# Altium schematic sheet Battery Protection.SchDoc
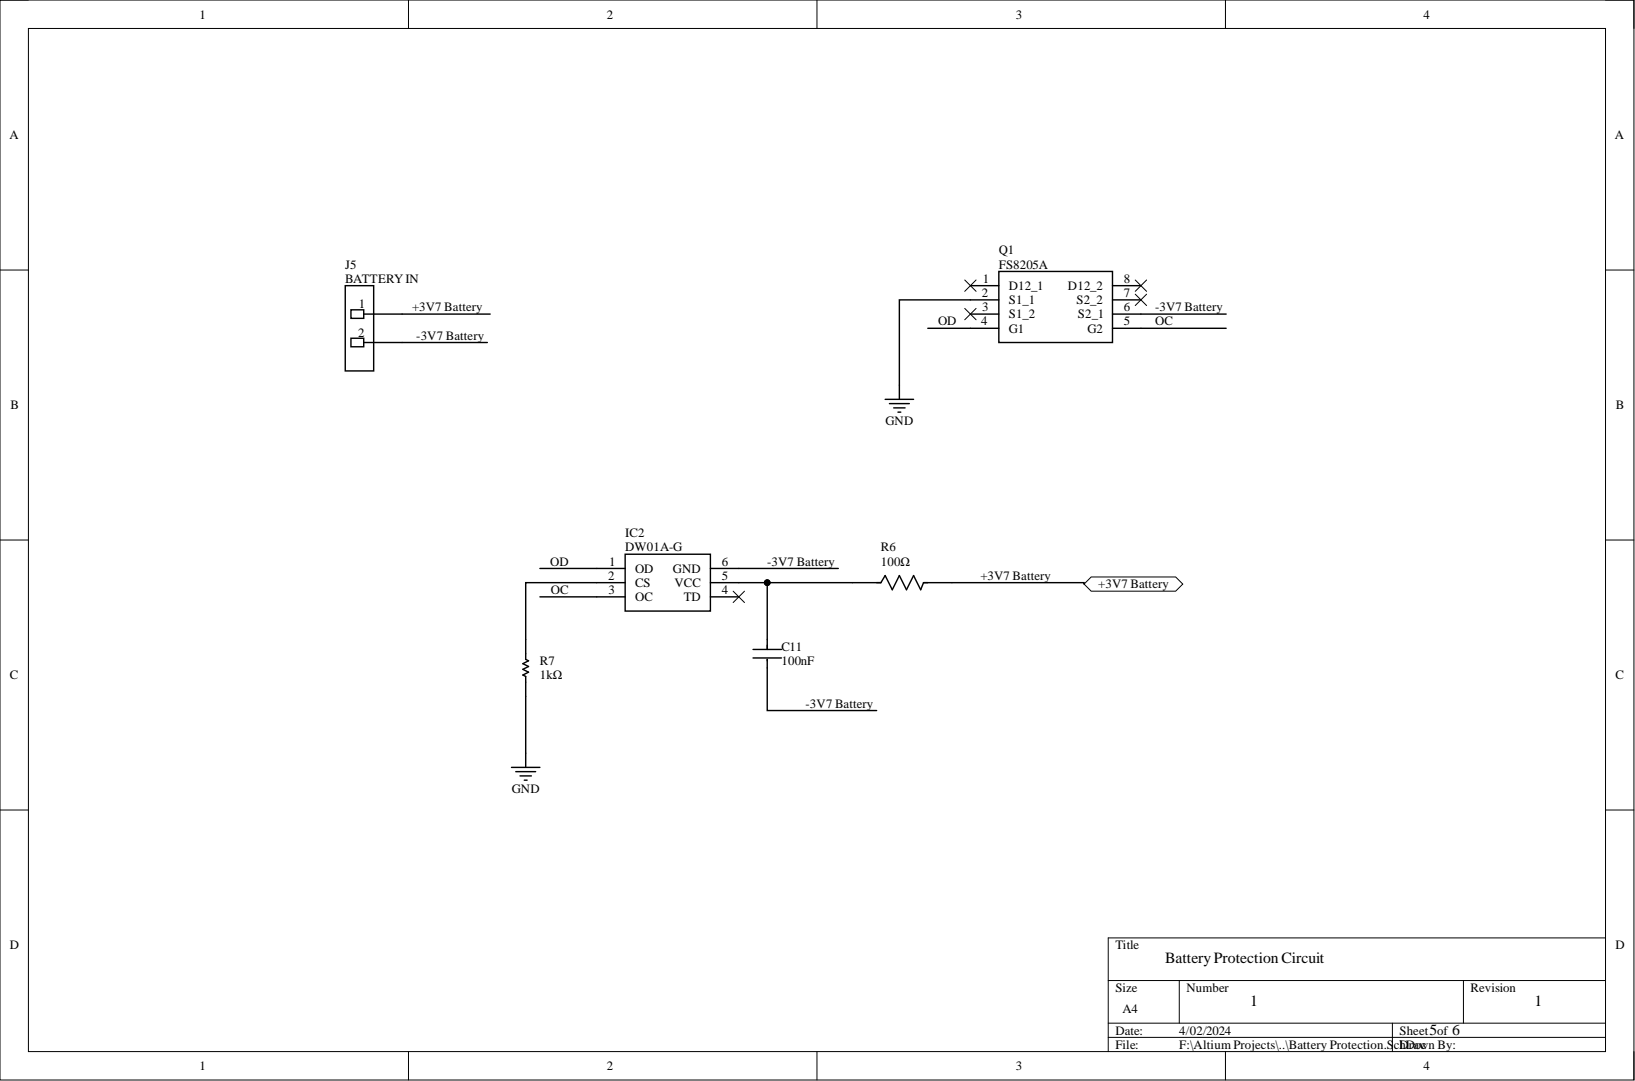
[diagram p0: Battery Protection.SchDoc]

## Parts
C11  value 100nF  [FP-C1206C-EB-MFG]  (hidden comment: C1206C104K5RAC7210)
IC2  DW01A-G  [SOT95P280X135-6N]
J5  BATTERY IN  [FP-B2B-EH-A_LF_SN-MFG]
Q1  FS8205A  [SOP65P640X120-8N]
R6  Resistance: 100Ω  [FP-RNCP1206-IPC_C]  (hidden comment: RNCP1206FTD100R)
R7  value 1kΩ  [RESC3216X70X40NL20T20]  (hidden comment: RK73B2BTTDD102J)

## Ports
+3V7 Battery

## Nets
GND: Q1.2(S1_1) R7.1
+3V7 Battery: J5.1 R6.2 ; port +3V7 Battery
-3V7 Battery: C11.2 IC2.6(GND) J5.2 Q1.6(S2_1)
OC: IC2.3(OC) Q1.5(G2)
OD: IC2.1(OD) Q1.4(G1)
NetC11_1: C11.1 IC2.5(VCC) R6.1
NetIC2_2: IC2.2(CS) R7.2
NetIC2_4: IC2.4(TD)
NetQ1_1: Q1.1(D12_1)
NetQ1_3: Q1.3(S1_2)
NetQ1_7: Q1.7(S2_2)
NetQ1_8: Q1.8(D12_2)

## Library data of the parts
C11 = CMP-03020-003377-1: CAP CER 0.1UF 50V X7R 1206; Manufacturer: KEMET; Automotive Grade: Grade 0; Capacitance: 0.10 μF; Material: Ceramic; Temperature Coefficient: 15%; Tolerance: 0.1; Automotive: Yes; Category: Cap; Component Kind: Standard; ComponentLink2Description: Datasheet; ComponentLink2URL: https://content.kemet.com/datasheets/KEM_C1007_X8R_ULTRA_150C_SMD.pdf; Device Class L1: Passive Components; Device Class L2: Capacitors; Device Class L3: Ceramic Capacitors; Digikey Description: CAP CER 0.1UF 50V X7R 1206; Height: 0.88mm; Ipc Land Pattern Name: CAPC320160X78; Lead Free: Yes; Library Name: Altium Content Vault; Manufacturer Part Number: C1206C104K5RAC7210; Mouser Description: Multilayer Ceramic Capacitors MLCC - SMD/SMT 50volts 0.1uF X7R 10%; Octopart: https://octopart.com/c1206c104k5rac7210-kemet-3919856; Package: C1206C-EB; RoHS: TRUE; Temperature: -55°C to +150°C; Temperature Characteristic: X7R; Temperature Range High: +150°C; Temperature Range Low: -55°C; Voltage Rating: 50 V
IC2 = DW01A-G: Integrated Circuit; Manufacturer_Name: Fortune Semiconductor Corporation; Component Kind: Standard; Datasheet Link: http://acoptex.com/uploads/DW01A.pdf; Height: 1.35mm; Manufacturer_Part_Number: DW01A-G
J5 = CMP-17439-000026-1: B2B-EH-A(LF)(SN), CONN HEADER VERT 2POS 2.5MM; Connector Type: Plug; Contact Resistance: 20mR; Current Rating: 3A; Gender: Male; Max Voltage Rating (AC): 250V; Number of Contacts: 2; Color: Natural; Component Kind: Standard; Contact Material: Copper Alloy; Contact Mating Length: 0.13inch; Contact Plating: Tin; Contact Type: Male Pin; Depth: 3.8mm; Fastening Type: Detent; Housing Color: White; Housing Material: Nylon; Lead Free: Lead Free; Length: 7.5mm; Library Name: Altium Content Vault; Max Current Rating: 3A; Max Operating Temperature: 85°C; Min Operating Temperature: -25°C; Mount: Through Hole; Number of Positions: 2; Number of Rows: 1; Orientation: Straight; Packaging: Bulk; Pitch: 2.5mm; Series: EH; Termination: Press-Fit; Voltage Rating: 250V
Q1 = FS8205A: MOSFET (N-Channel); Manufacturer_Name: FSC; Component Kind: Standard; Datasheet Link: https://datasheet.lcsc.com/szlcsc/Fortune-Semicon-FS8205A_C16052.pdf; Height: 1.2mm; Manufacturer_Part_Number: FS8205A
R6 = CMP-26527-003782-1: 100Ω ±1% 0.5W 1206 High Power Anti-Sulfur Thin Film Chip Resistor; Max Power Dissipation: 500mW; Temperature Coefficient: 100ppm/°C; Case Code (Imperial): 1206; Case Code (Metric): 3216; Case/Package: 1206; Component Kind: Standard; Contact Plating: Tin; Features: Moisture Resistant; Height: 0.024inch; Length: 0.122inch; Library Name: Altium Content Vault; Max Operating Temperature: 155°C; Min Operating Temperature: -55°C; Mount: Surface Mount; Number of Terminations: 2; Packaging: Tape and Reel; Radiation Hardening: No; Resistor Type: Thin Film; RoHS Compliant: Yes; Series: RNCP; Tolerance: 1%; Width: 0.059inch
R7 = CMP-2003-04513-1: Manufacturer: KOA Speer; Component Kind: Standard; Library Name: Altium Content Vault; Part Number: RK73B2BTTDD102J
